# Altium schematic sheet INTERFACE.SchDoc(INTERFACE)
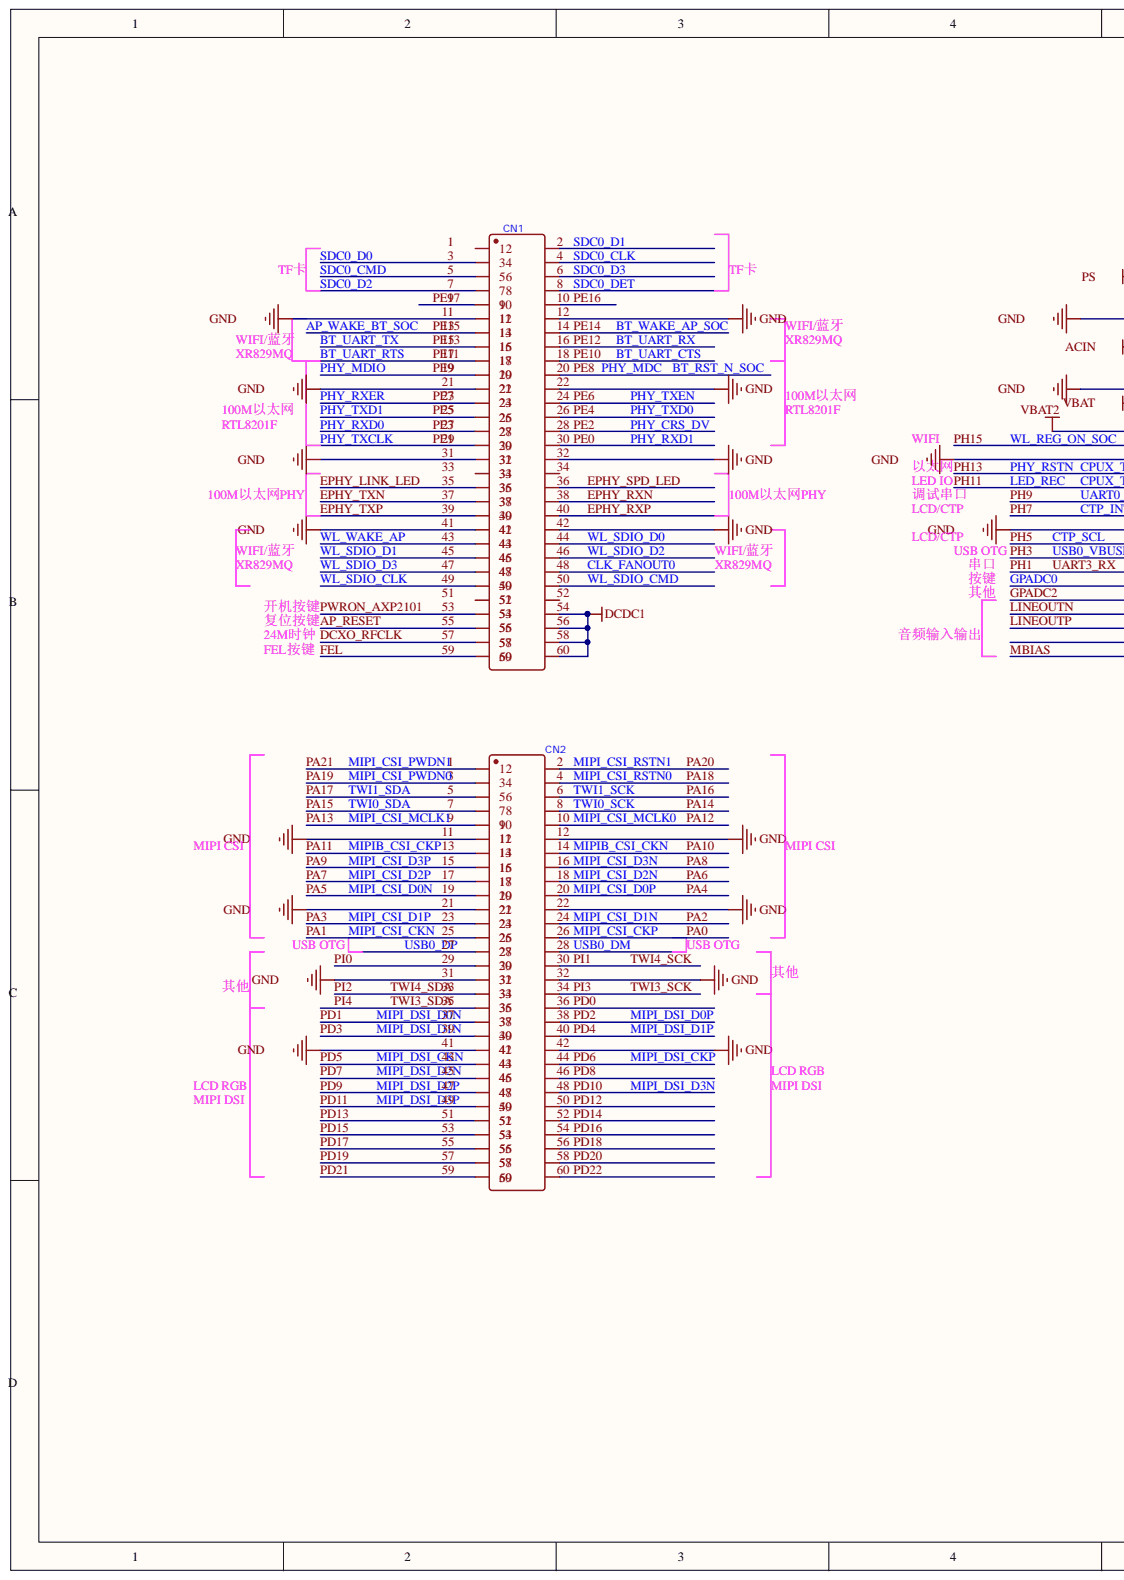
[diagram p0: INTERFACE.SchDoc, left]
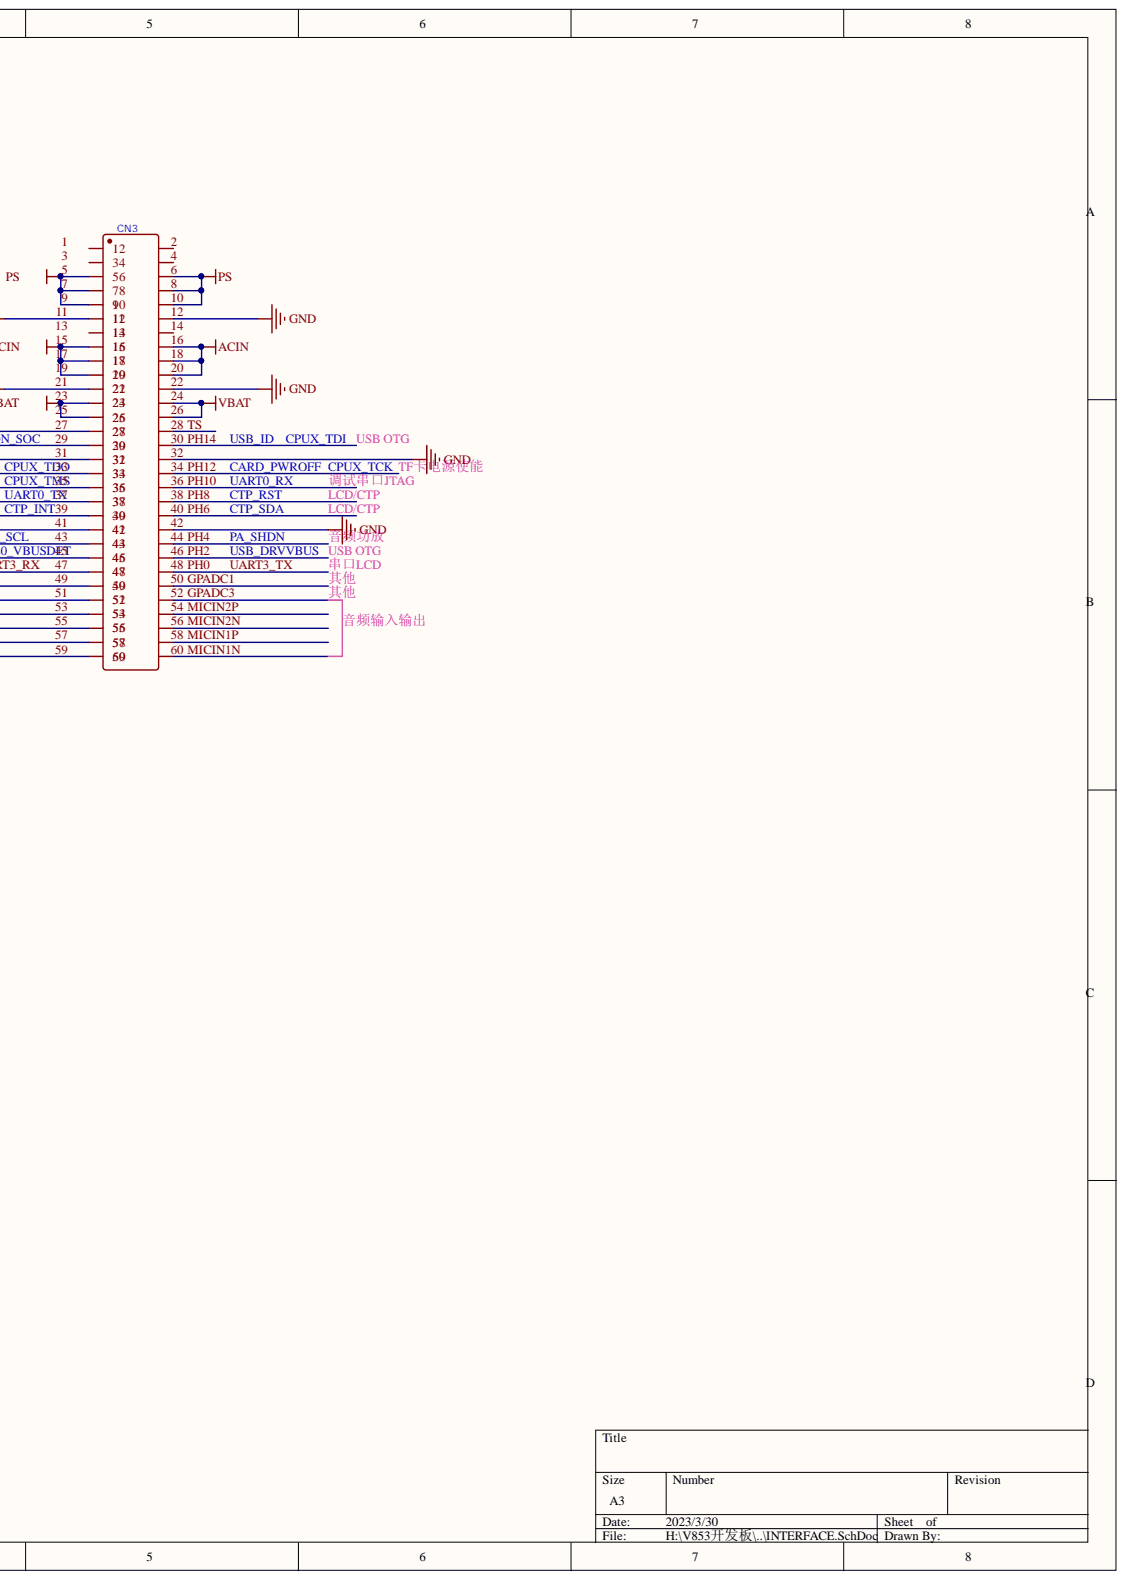
[diagram p1: INTERFACE.SchDoc, right]

## Parts
CN1  (no value)  [CONN-SMD_60P-P0.80_XKB_X0802WVS-60ADS-LPV01]
CN2  (no value)  [CONN-SMD_60P-P0.80_XKB_X0802WVS-60ADS-LPV01]
CN3  (no value)  [CONN-SMD_60P-P0.80_XKB_X0802WVS-60ADS-LPV01]

## Nets
ACIN: CN3.15 CN3.16 CN3.17 CN3.18 CN3.19 CN3.20
DCDC1: CN1.54 CN1.56 CN1.58 CN1.60
GND: CN1.11 CN1.12 CN1.21 CN1.22 CN1.31 CN1.32 CN1.41 CN1.42 CN2.11 CN2.12 CN2.21 CN2.22 CN2.31 CN2.32 CN2.41 CN2.42 CN3.11 CN3.12 CN3.21 CN3.22 CN3.31 CN3.32 CN3.41 CN3.42
PS: CN3.5(5_6) CN3.6 CN3.7(7_8) CN3.8 CN3.9 CN3.10
VBAT: CN3.23 CN3.24 CN3.25 CN3.26
VBAT2: CN3.27
AP_RESET: CN1.55
AP_WAKE_BT_SOC: CN1.13
BT_RST_N_SOC: CN1.20
BT_UART_CTS: CN1.18
BT_UART_RTS: CN1.17
BT_UART_RX: CN1.16
BT_UART_TX: CN1.15
BT_WAKE_AP_SOC: CN1.14
CARD_PWROFF: CN3.34
CLK_FANOUT0: CN1.48
CPUX_TDI: CN3.30
CPUX_TDO: CN3.33
CPUX_TMS: CN3.35
CTP_INT: CN3.39
CTP_RST: CN3.38
CTP_SCL: CN3.43
CTP_SDA: CN3.40
DCXO_RFCLK: CN1.57
EPHY_LINK_LED: CN1.35
EPHY_RXN: CN1.38
EPHY_RXP: CN1.40
EPHY_SPD_LED: CN1.36
EPHY_TXN: CN1.37
EPHY_TXP: CN1.39
FEL: CN1.59
GPADC0: CN3.49
GPADC1: CN3.50
GPADC2: CN3.51
GPADC3: CN3.52
LINEOUTN: CN3.53
LINEOUTP: CN3.55
MBIAS: CN3.59
MICIN1N: CN3.60
MICIN1P: CN3.58
MICIN2N: CN3.56
MICIN2P: CN3.54
MIPI_CSI_CKN: CN2.25
MIPI_CSI_CKP: CN2.26
MIPI_CSI_D0N: CN2.19
MIPI_CSI_D0P: CN2.20
MIPI_CSI_D1N: CN2.24
MIPI_CSI_D1P: CN2.23
MIPI_CSI_D2N: CN2.18
MIPI_CSI_D2P: CN2.17
MIPI_CSI_D3N: CN2.16
MIPI_CSI_D3P: CN2.15
MIPI_CSI_MCLK0: CN2.10
MIPI_CSI_MCLK1: CN2.9
MIPI_CSI_PWDN0: CN2.3(3_4)
MIPI_CSI_PWDN1: CN2.1(1_2)
MIPI_CSI_RSTN0: CN2.4
MIPI_CSI_RSTN1: CN2.2
MIPI_DSI_CKN: CN2.43
MIPI_DSI_CKP: CN2.44
MIPI_DSI_D0N: CN2.37
MIPI_DSI_D0P: CN2.38
MIPI_DSI_D1N: CN2.39
MIPI_DSI_D1P: CN2.40
MIPI_DSI_D2N: CN2.45
MIPI_DSI_D2P: CN2.47
MIPI_DSI_D3N: CN2.48
MIPI_DSI_D3P: CN2.49
MIPIB_CSI_CKN: CN2.14
MIPIB_CSI_CKP: CN2.13
PA14: CN2.8
PA15: CN2.7(7_8)
PA16: CN2.6
PA17: CN2.5(5_6)
PA_SHDN: CN3.44
PD0: CN2.36
PD8: CN2.46
PD12: CN2.50
PD13: CN2.51
PD14: CN2.52
PD15: CN2.53
PD16: CN2.54
PD17: CN2.55
PD18: CN2.56
PD19: CN2.57
PD20: CN2.58
PD21: CN2.59
PD22: CN2.60
PE0: CN1.30
PE1: CN1.29
PE2: CN1.28
PE3: CN1.27
PE4: CN1.26
PE5: CN1.25
PE6: CN1.24
PE7: CN1.23
PE9: CN1.19
PE16: CN1.10
PE17: CN1.9
PH0: CN3.48
PH1: CN3.47
PH2: CN3.46
PH3: CN3.45
PH9: CN3.37
PH10: CN3.36
PH15: CN3.29
PI0: CN2.29
PI1: CN2.30
PI2: CN2.33
PI3: CN2.34
PI4: CN2.35
PWRON_AXP2101: CN1.53
SDC0_CLK: CN1.4
SDC0_CMD: CN1.5(5_6)
SDC0_D0: CN1.3(3_4)
SDC0_D1: CN1.2
SDC0_D2: CN1.7(7_8)
SDC0_D3: CN1.6
SDC0_DET: CN1.8
TS: CN3.28
USB0_DM: CN2.28
USB0_DP: CN2.27
WL_SDIO_CLK: CN1.49
WL_SDIO_CMD: CN1.50
WL_SDIO_D0: CN1.44
WL_SDIO_D1: CN1.45
WL_SDIO_D2: CN1.46
WL_SDIO_D3: CN1.47
WL_WAKE_AP: CN1.43

Not connected: CN1 1(1_2) 33 34 51 52; CN3 1(1_2) 2 3(3_4) 4 13 14 57

## Library data of the parts
CN1-CN3 = X0802WVS-60ADS-LPV01: Component Kind: Standard
